# Altium schematic sheet JacdacBrainF4 41.SchDoc
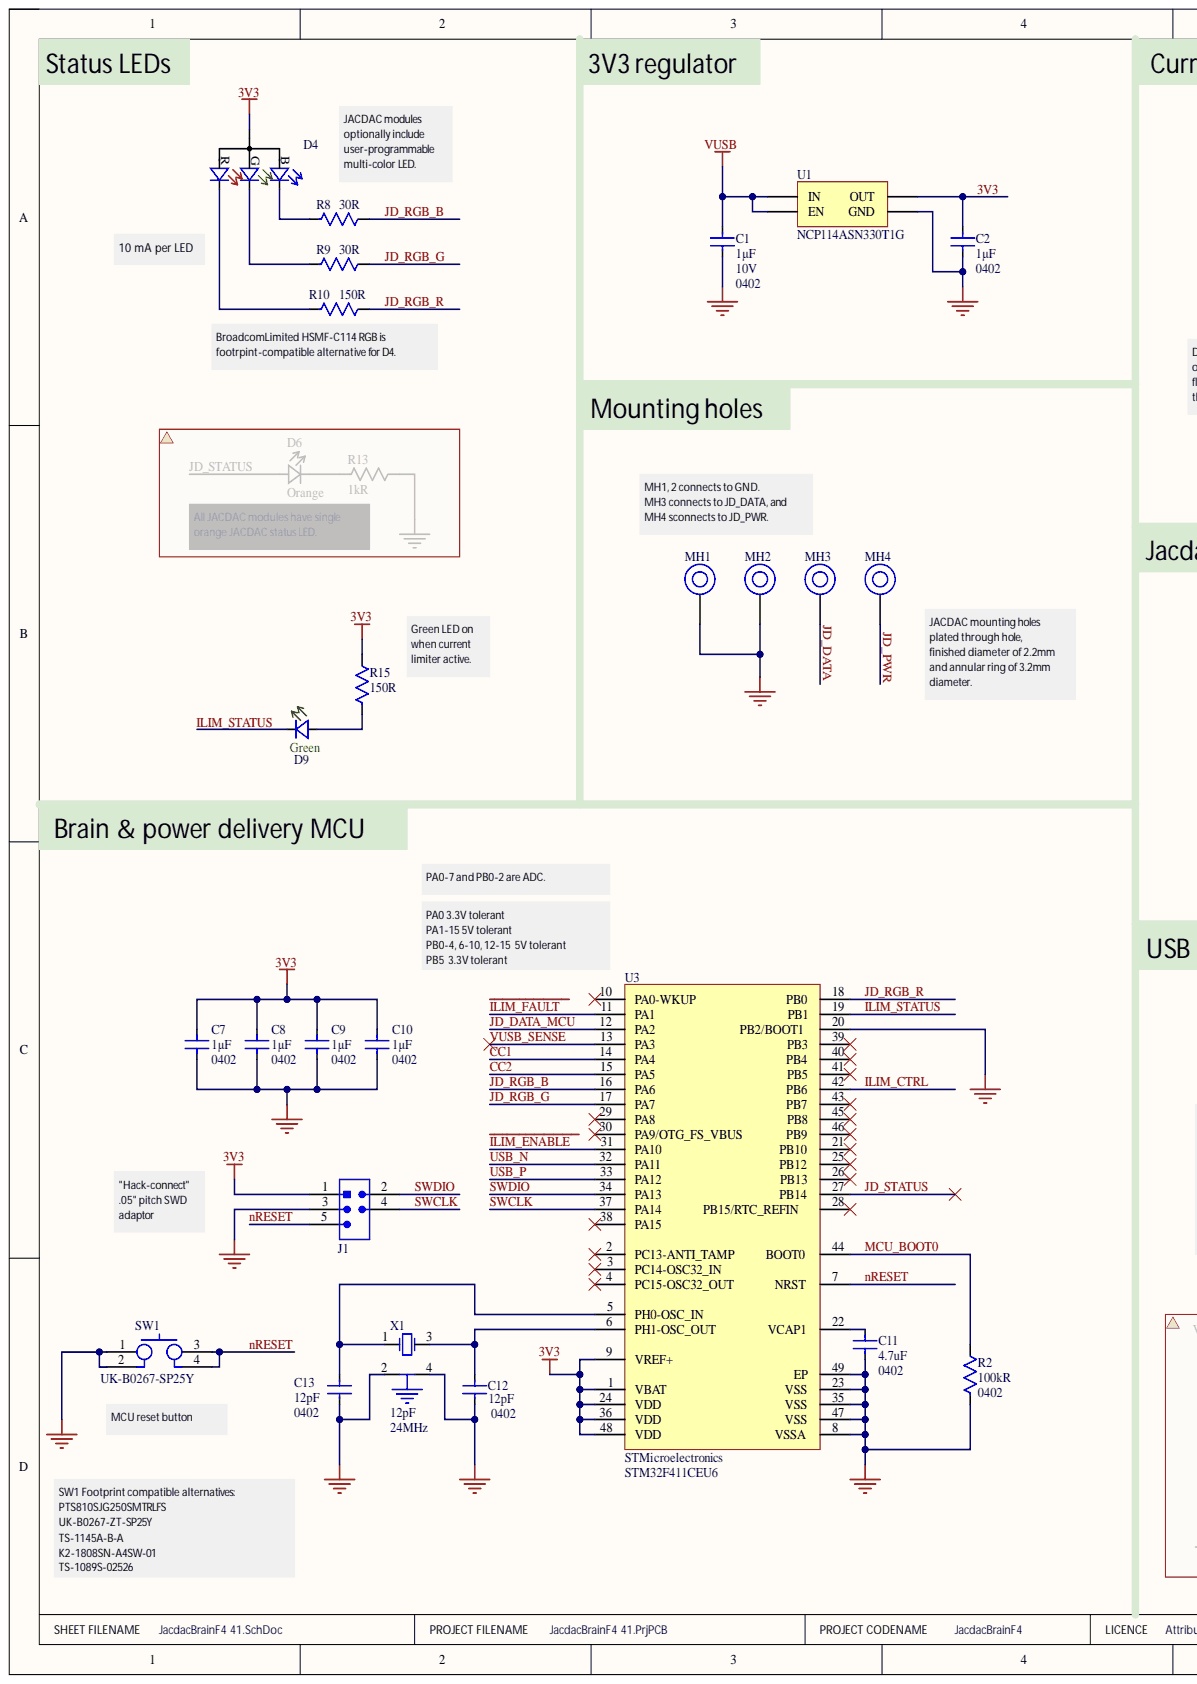
[diagram p0: JacdacBrainF4 41.SchDoc, left]
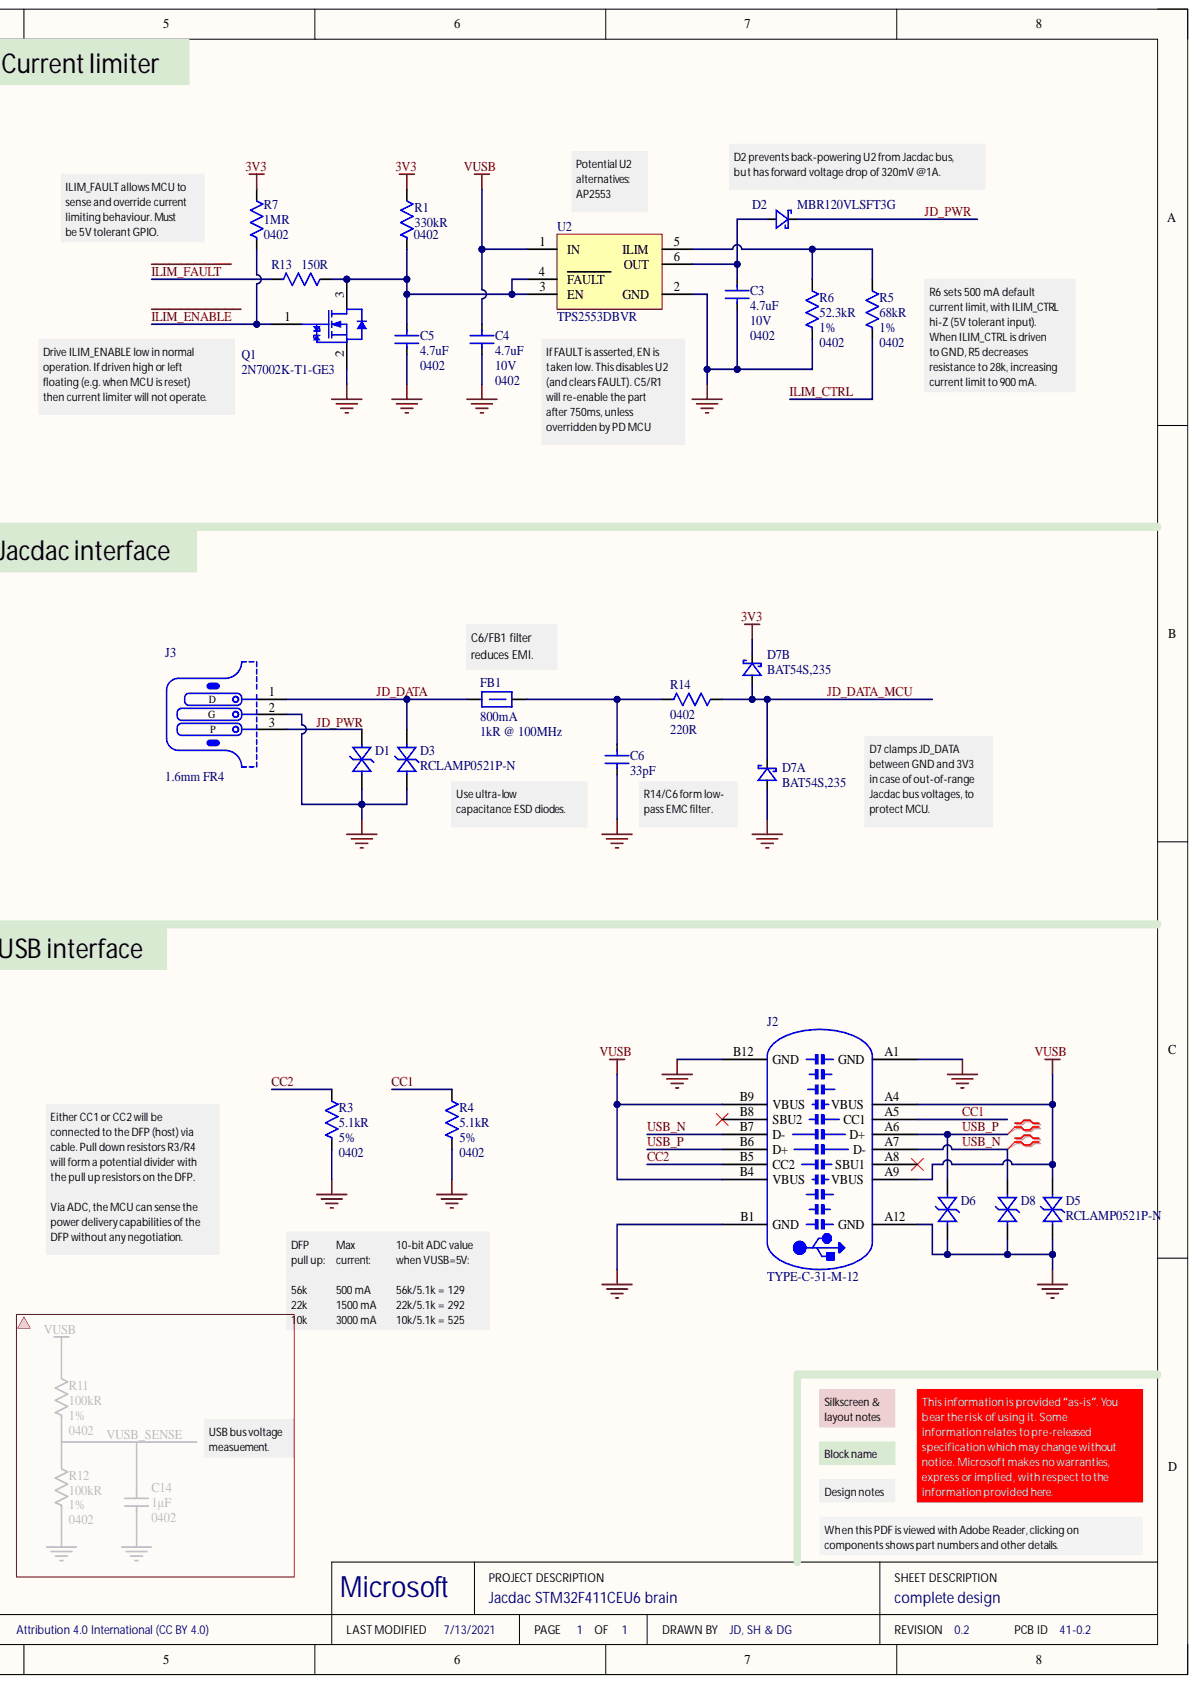
[diagram p1: JacdacBrainF4 41.SchDoc, right]

## Parts
C1  Capacitance: 1µF  Case Code (Imperial): 0402  Voltage Rating: 10V
C2  Capacitance: 1µF  Case Code (Imperial): 0402
C3  Capacitance: 4.7uF  Case Code (Imperial): 0402  Voltage Rating: 10V
C4  Capacitance: 4.7uF  Case Code (Imperial): 0402  Voltage Rating: 10V
C5  Capacitance: 4.7uF  Case Code (Imperial): 0402
C6  Capacitance: 33pF
C7  Capacitance: 1µF  Case Code (Imperial): 0402
C8  Capacitance: 1µF  Case Code (Imperial): 0402
C9  Capacitance: 1µF  Case Code (Imperial): 0402
C10  Capacitance: 1µF  Case Code (Imperial): 0402
C11  Capacitance: 4.7uF  Case Code (Imperial): 0402
C12  Capacitance: 12pF  Case Code (Imperial): 0402
C13  Capacitance: 12pF  Case Code (Imperial): 0402
D1  (no value)
D2
D2  Manufacturer Part Number: MBR120VLSFT3G
D3  Manufacturer Part Number: RCLAMP0521P-N
D4  (no value)
D5  Manufacturer Part Number: RCLAMP0521P-N
D6  (no value)
D7  Manufacturer Part Number: BAT54S,235  (parts A,B)
D8  (no value)
D9  Color: Green
FB1  Current Rating: 800mA  Impedance: 1kR @ 100MHz
J1  (no value)
J2  Manufacturer Part Number: TYPE-C-31-M-12
J3  PCB Type: 1.6mm FR4
MH1  (no value)
MH2  (no value)
MH3  (no value)
MH4  (no value)
Q1  Manufacturer Part Number: 2N7002K-T1-GE3
R1  Case Code (Imperial): 0402  Resistance: 330kR
R2  Case Code (Imperial): 0402  Resistance: 100kR
R3  Case Code (Imperial): 0402  Resistance: 5.1kR  Resistance Tolerance: 5%
R4  Case Code (Imperial): 0402  Resistance: 5.1kR  Resistance Tolerance: 5%
R5  Case Code (Imperial): 0402  Resistance: 68kR  Tolerance: 1%
R6  Case Code (Imperial): 0402  Resistance: 52.3kR  Tolerance: 1%
R7  Case Code (Imperial): 0402  Resistance: 1MR
R8  Resistance: 30R
R9  Resistance: 30R
R10  Resistance: 150R
R13  Resistance: 150R
R14  Case Code (Imperial): 0402  Resistance: 220R
R15  Resistance: 150R
SW1  Manufacturer Part Number: UK-B0267-SP25Y
U1  Manufacturer Part Number: NCP114ASN330T1G
U2  Manufacturer Part Number 1: TPS2553DBVR
U3  Manufacturer: STMicroelectronics  Manufacturer Part Number: STM32F411CEU6
X1  Frequency: 24MHz  Loading capacitance: 12pF

## Nets
GND: C1.1 C2.1 C3.1 C4.1 C5.1 C6.1 C7.1 C8.1 C9.1 C10.1 C11.1 C12.1 C13.1 D1.2 D3.2 D5.2 D6.2 D7.1 D8.2 J1.3 J2.A1(GND) J2.A12(GND) J2.B1(GND) J2.B12(GND) J3.2 MH1.1 MH2.1 Q1.2 R2.1 R3.2 R4.2 R6.1 SW1.1 SW1.2 U1.2(GND) U2.2(GND) U3.8(VSSA) U3.20(PB2/BOOT1) U3.23(VSS) U3.35(VSS) U3.47(VSS) U3.49(EP) X1.2 X1.4
VUSB: C1.2 C4.2 D5.1 J2.A4(VBUS) J2.A9(VBUS) J2.B4(VBUS) J2.B9(VBUS) U1.1(IN) U1.3(EN) U2.1(IN)
3V3: C2.2 C7.2 C8.2 C9.2 C10.2 D4.1 D7.2 J1.1 R1.1 R7.1 R15.2 U1.5(OUT) U3.1(VBAT) U3.9(VREF+) U3.24(VDD) U3.36(VDD) U3.48(VDD)
~{ILIM_ENABLE}: Q1.1 R7.2 U3.31(PA10)
~{ILIM_FAULT}: R13.1 U3.11(PA1)
CC1: J2.A5(CC1) R4.1 U3.14(PA4)
CC2: J2.B5(CC2) R3.1 U3.15(PA5)
ILIM_CTRL: R5.1 U3.42(PB6)
ILIM_STATUS: D9.1 U3.19(PB1)
JD_DATA: D3.1 FB1.1 J3.1 MH3.1
JD_DATA_MCU: D7.3 R14.1 U3.12(PA2)
JD_PWR: D1.1 D2.1 J3.3 MH4.1
JD_RGB_B: R8.2 U3.16(PA6)
JD_RGB_G: R9.2 U3.17(PA7)
JD_RGB_R: R10.2 U3.18(PB0)
JD_STATUS: U3.27(PB14)
MCU_BOOT0: R2.2 U3.44(BOOT0)
nRESET: J1.5 SW1.3 SW1.4 U3.7(NRST)
SWCLK: J1.4 U3.37(PA14)
SWDIO: J1.2 U3.34(PA13)
USB_N: D8.1 J2.A7(D-) J2.B7(D-) U3.32(PA11)
USB_P: D6.1 J2.A6(D+) J2.B6(D+) U3.33(PA12)
VUSB_SENSE: U3.13(PA3)
NetC3_2: C3.2 D2.2 U2.6(OUT)
NetC5_2: C5.2 Q1.3 R1.2 R13.2 U2.3(EN) U2.4(FAULT)
NetC6_2: C6.2 FB1.2 R14.2
NetC11_2: C11.2 U3.22(VCAP1)
NetC12_2: C12.2 U3.6(PH1-OSC_OUT) X1.3
NetC13_2: C13.2 U3.5(PH0-OSC_IN) X1.1
NetD4_2: D4.2 R10.1
NetD4_3: D4.3 R9.1
NetD4_4: D4.4 R8.1
NetD9_2: D9.2 R15.1
NetR5_2: R5.2 R6.2 U2.5(ILIM)

Not connected: J2 A8(SBU1) B8(SBU2); U3 2(PC13-ANTI_TAMP) 3(PC14-OSC32_IN) 4(PC15-OSC32_OUT) 10(PA0-WKUP) 21(PB10) 25(PB12) 26(PB13) 28(PB15/RTC_REFIN) 29(PA8) 30(PA9/OTG_FS_VBUS) 38(PA15) 39(PB3) 40(PB4) 41(PB5) 43(PB7) 45(PB8) 46(PB9)

## Library data of the parts
C1 C2 C7-C10 = (no symbol name): CAP CER 1UF 10V X5R 0402; Manufacturer: Samsung; Manufacturer Part Number: CL05A105KP5NNNC; Material: Ceramic; Tolerance: 10%; Case Code (Metric): 1005; Case/Package: 0402; Category: Capacitors; ComponentLink1Description: Online datasheet; ComponentLink1URL: https://datasheet.ciiva.com/15195/getdatasheetpartid-358390-15195630.pdf; Contact Plating: Tin; Dielectric: X5R; Max Operating Temperature: 85°C; Min Operating Temperature: -55°C; Supplier 1: Digi-Key; Supplier 2: Mouser; Supplier 3: Farnell; Supplier Part Number 1: 1276-1076-1-ND; Supplier Part Number 2: 187-CL05A105KP5NNNC; Supplier Part Number 3: 3013329
  C2 C7-C10: Voltage Rating: 10V
C3-C5 C11 = (no symbol name): CAP CER 4.7UF 10V X5R 0402; Manufacturer: Samsung; Manufacturer Part Number: CL05A475MP5NRNC; Tolerance: 20%; Case Code (Metric): 1005; Case/Package: 0402; Category: Ceramic Capacitors; ComponentLink1Description: Online datasheet; ComponentLink1URL: https://datasheet.ciiva.com/25786/cl05a475mp5nrnc-25786963.pdf; Dielectric: X5R; Max Operating Temperature: 85°C; Min Operating Temperature: -55°C; RoHS Compliant: Yes; Series: CL; Supplier 1: Digi-Key; Supplier 2: Future Electronics; Supplier Part Number 1: 1276-1482-2-ND; Supplier Part Number 2: 2103694
  C5 C11: Voltage Rating: 10V
C6 = (no symbol name): CAP CER 33PF 50V NP0 0402; Manufacturer: Samsung; Manufacturer Part Number: CL05C330JB51PNC; Tolerance: 5%; Case Code (Imperial): 0402; Case Code (Metric): 1005; Case/Package: 0402; Category: Capacitors; ComponentLink1Description: Samsung datasheet online; ComponentLink1URL: http://www.samsungsem.com/kr/support/library/product-catalog/mlcc.jsp; Max Operating Temperature: 125°C; Min Operating Temperature: -55°C; Ratings: AEC-Q200; Supplier 1: Digi-Key; Supplier 2: Mouser; Supplier 3: Future Electronics; Supplier Part Number 1: 1276-6515-1-ND; Supplier Part Number 2: 187-CL05C330JB51PNC; Supplier Part Number 3: 6022520; Voltage Rating: 50V
C12 C13 = (no symbol name): CAP CER 12PF 50V C0G/NP0 0402; Manufacturer: Samsung; Manufacturer Part Number: CL05C120JB5NNNC; Dielectric: C0G; Material: Ceramic; Temperature Coefficient: 300ppm/°C; Tolerance: 5%; Case Code (Metric): 1005; Case/Package: 0402; Category: Ceramic Capacitors; ComponentLink1Description: Online datasheet; ComponentLink1URL: https://datasheet.ciiva.com/25806/cl05c120jb5nnnc-25806484.pdf; Construction: Flat; Contact Plating: Tin; Max Operating Temperature: 125°C; Min Operating Temperature: -55°C; RoHS Compliant: Yes; Series: CL; Supplier 1: Digi-Key; Supplier 2: Mouser; Supplier Part Number 1: 1276-1178-1-ND; Supplier Part Number 2: 187-CL05C120JB5NNNC; Voltage: 50V; Voltage Rating: 50V; Voltage Rating (DC): 50V
D1 D6 D8 = (no symbol name): Bidirectional 5V (Max) 6V 16V 3.5A (8/20us) 0402 TVS RoHS; Manufacturer: Born Semiconductor; Manufacturer Part Number: RCLAMP0521P-N; ComponentLink1Description: Online datasheet; ComponentLink1URL: https://datasheet.lcsc.com/szlcsc/Bourne-Semicon-Shenzhen-RCLAMP0521P-N_C316043.pdf; Package: 0402; Supplier1: LCSC; Supplier1 Part Number: C316043
D2 = (no symbol name): DIODE SCHOTTKY 20V 1A SOD123FL; Manufacturer: ON Semiconductor; Element Configuration: Single; Forward Voltage: 340mV; Max Forward Surge Current (Ifsm): 45A; Max Reverse Leakage Current: 600uA; Polarity: Standard; Average Rectified Current: 1A; Category: Schottky Diodes; ComponentLink4Description: On Semi datasheet online; ComponentLink4URL: https://datasheet.ciiva.com/6380/mbr120vlsft1-d-6380910.pdf; Current Rating: 1A; Forward Current: 1A; Halogen Free: Halogen Free; Max Operating Temperature: 125°C; Max Repetitive Reverse Voltage (Vrrm): 20V; Max Reverse Voltage (DC): 20V; Max Surge Current: 45A; Min Operating Temperature: -65°C; Number of Pins: 2; Output Current: 1A; Peak Non-Repetitive Surge Current: 45A; Peak Reverse Current: 600uA; Supplier 1: Digi-Key; Supplier 2: Mouser; Supplier 3: RSComponents; Supplier Part Number 1: MBR120VLSFT3GOSCT-ND; Supplier Part Number 2: 863-MBR120VLSFT3G; Supplier Part Number 3: 1867479; Voltage Rating (DC): 20V
D3 D5 = (no symbol name): Bidirectional 5V (Max) 6V 16V 3.5A (8/20us) 0402 TVS RoHS; Manufacturer: Born Semiconductor; ComponentLink1Description: Online datasheet; ComponentLink1URL: https://datasheet.lcsc.com/szlcsc/Bourne-Semicon-Shenzhen-RCLAMP0521P-N_C316043.pdf; Package: 0402; Supplier1: LCSC; Supplier1 Part Number: C316043
D4 = (no symbol name): 1615 Light Emitting Diodes (LED) RoHS; Manufacturer: TUOZHAN; Manufacturer Part Number: TZ-P4-1615RGBTCA1-0.55T; Category: Optoelectronics; ComponentLink1Description: Online datasheet; ComponentLink1URL: https://datasheet.lcsc.com/szlcsc/2009041238_TUOZHAN-TZ-P4-1615RGBTCA1-0-55T_C779813.pdf; Package: 1615; Size / Dimension: 1.6x1.5mm; Supplier 1: LCSC; Supplier 1 Part Number: C779813
D7 = (no symbol name): DIODE ARRAY SCHOTTKY 30V SOT23; Manufacturer: Nexperia; Category: Rectifier Diodes; ComponentLink1Description: NXP datasheet online; ComponentLink1URL: https://assets.nexperia.com/documents/data-sheet/BAT54_SER.pdf; Supplier 1: Digi-Key; Supplier 2: Mouser; Supplier 3: RSComponents; Supplier Part Number 1: 1727-1868-1-ND; Supplier Part Number 2: 771-BAT54S235; Supplier Part Number 3: 1707990
D9 = (no symbol name): LED GREEN DIFFUSED 0603 SMD; Manufacturer: Everlight Elec; Manufacturer Part Number: 19-217/GHC-YR1S2/3T; Case Code (Imperial): 0603; Case/Package: 0603; Colour: Green; ComponentLink1Description: Online datasheet; ComponentLink1URL: https://datasheet.lcsc.com/lcsc/1811101510_Everlight-Elec-19-217-GHC-YR1S2-3T_C72043.pdf; Supplier 1: LCSC; Supplier 1 Part Number: C72043
FB1 = (no symbol name): 0603 1k@100MHz 0.8A chip; Manufacturer: TDK; Manufacturer Part Number: MPZ1608S102ATA00; Core Material: Ferrite; DC Resistance (DCR): 300mR; Tolerance: 25%; Case Code (Imperial): 0603; Case Code (Metric): 1608; Case/Package: 0603; ComponentLink2Description: datasheet online; ComponentLink2URL: https://www.mouser.co.uk/datasheet/2/400/eads_commercial_power_mpz1608_en-923650.pdf; ComponentLink3Description: datasheet; Max DC Current: 800mA; Supplier 1: Digi-Key; Supplier 2: Mouser; Supplier Part Number 1: 445-8694-1-ND; Supplier Part Number 2: 810-MPZ1608S102ATA00; ComponentLink3URL: Y:\System\Altium\Libraries 2014\TDK\Datasheets\eads_commercial_power_mpz1608_en-923650.pdf
J1 = (no symbol name): Hack Connect Mini 1.27 mm pitch 2-row
J2 = (no symbol name): USB - Type C Female 16 USB Connectors RoHS; Manufacturer: Korean Hroparts Elec; Gender: Receptacle; Number of Positions: 16; Category: USB Connectors; ComponentLink1Description: Online datasheet; ComponentLink1URL: https://datasheet.lcsc.com/szlcsc/1811131825_Korean-Hroparts-Elec-TYPE-C-31-M-12_C165948.pdf; Orientation: Right Angle; Supplier 1: LCSC; Supplier 1 Part Number: C165948
J3 = (no symbol name): 3 pin jacdac edge connector 1.27mm pitch; Manufacturer Part Number: JACDAC_EDGE_CONNECTOR; ComponentLink1Description: JACDAC PCB edge connector spec onlin; ComponentLink1URL: https://github.com/microsoft/jacdac-mdk/blob/main/connector/JACDAC_PCB_Edge_Connector_Drawing_JD-PEC-01_rev_01.pdf; ComponentLink2Description: Yuliang JACDAC cable connector drawing online; ComponentLink2URL: https://github.com/microsoft/jacdac-mdk/blob/main/connector/JACDAC-Connector-by-Yuliang-2020-11-28.pdf
MH1-MH4 = (no symbol name): Mounting Hole 5.0mm keepout, 3.0mm annualar ring and 2.1mm Drill PTH
Q1 = (no symbol name): Trans MOSFET N-CH Si 60V 0.3A 3-Pin SOT-23 T/R; Manufacturer: Vishay; Continuous Drain Current (ID): 300mA; Drain to Source Resistance: 2R; Element Configuration: Single; Gate to Source Voltage (Vgs): 20V; Input Capacitance: 30pF; Max Power Dissipation: 350mW; Nominal Vgs: 2V; Number of Channels: 1; Threshold Voltage: 1V; Turn-Off Delay Time: 35ns; Turn-On Delay Time: 25ns; Case/Package: SOT-23; Category: MOSFETs; ComponentLink1Description: Online datasheet; ComponentLink1URL: https://datasheet.ciiva.com/2957/2n7002k-2957216.pdf; Contact Plating: Tin,Matte; Drain to Source Breakdown Voltage: 60V; Drain to Source Voltage (Vdss): 60V; Max Operating Temperature: 150°C; Min Operating Temperature: -55°C; Number of Elements: 1; Number of Pins: 3; On-State Resistance: 2R; Power Dissipation: 350mW; Rds On Max: 2R; Supplier 1: Digi-Key; Supplier 2: RSComponents; Supplier Part Number 1: 2N7002K-T1-GE3CT-ND; Supplier Part Number 2: 7879058P; Voltage: 60V; Weight: 0.050717oz
R1 = (no symbol name): RES SMD 330K OHM 1% 1/16W 0402; Manufacturer: Yageo; Manufacturer Part Number: RC0402FR-07330KL; Max Power Dissipation: 63mW; Temperature Coefficient: 100ppm/°C; Voltage Rating: 50V; Case Code (Metric): 1005; Case/Package: 0402; Category: Chip SMD Resistors; ComponentLink1Description: Online datasheet; ComponentLink1URL: https://datasheet.ciiva.com/2518/pyu-r-int-thick-7-2518994.pdf?src-supplier=Digi-Key; Construction: Rectangular; Features: Moisture Resistant; Max Operating Temperature: 155°C; Min Operating Temperature: -55°C; Number of Terminations: 2; Power Rating: 63mW; Resistance Tolerance: 1%; Resistor Type: Thick Film; RoHS Compliant: Yes; Series: RC; Size Code: 0402; Supplier 1: Digi-Key; Supplier 2: Mouser; Supplier 3: Farnell; Supplier Part Number 1: 311-330KLRCT-ND; Supplier Part Number 2: 603-RC0402FR-07330KL; Supplier Part Number 3: 9239537; Termination: SMD/SMT; Tolerance: 1%
R2 = (no symbol name): RES SMD 100K OHM 1% 1/16W 0402; Manufacturer: Yageo; Manufacturer Part Number: RC0402FR-07100KL; Max Power Dissipation: 63mW; Temperature Coefficient: 100ppm/°C; Voltage Rating: 50V; Case Code (Metric): 1005; Case/Package: 0402; Category: Resistors; ComponentLink2Description: Online datasheet; ComponentLink2URL: https://datasheet.ciiva.com/2453/pyu-r-int-thick-7-2453361.pdf; Features: Moisture Resistant; Max Operating Temperature: 155°C; Min Operating Temperature: -55°C; Number of Terminations: 2; Power Rating: 63mW; Resistor Type: Thick Film; Supplier 1: Digi-Key; Supplier 2: Mouser; Supplier 3: Farnell; Supplier Part Number 1: 311-100KLRCT-ND; Supplier Part Number 2: 603-RC0402FR-07100KL; Supplier Part Number 3: 2131798; Termination: SMD/SMT; Tolerance: 1%; Working Voltage: 50V
R3 R4 = (no symbol name): RES SMD 5.1K OHM 5% 1/16W 0402; Manufacturer: Yageo; Manufacturer Part Number: RC0402JR-075K1L; Max Power Dissipation: 63mW; Power Rating: 62.5mW; Temperature Coefficient: 100ppm/°C; Voltage Rating: 50V; Case Code (Metric): 1005; Case/Package: 0402; Category: Chip SMD Resistors; ComponentLink2Description: Onnline datasheet; ComponentLink2URL: https://datasheet.ciiva.com/16740/pyu-rc0402-51-rohs-l-6-r-16740925.pdf; Construction: Rectangular; Features: Moisture Resistant; Max Operating Temperature: 155°C; Min Operating Temperature: -55°C; Number of Terminations: 2; Resistor Type: Thick Film; RoHS Compliant: Yes; Series: RC; Size Code: 0402; Supplier 1: Digi-Key; Supplier 2: Newark; Supplier 3: Mouser; Supplier 4: Farnell; Supplier 5: Farnell; Supplier Part Number 1: 311-5.1KJRCT-ND; Supplier Part Number 2: 68R0016; Supplier Part Number 3: 603-RC0402JR-075K1L; Supplier Part Number 4: 3495714; Supplier Part Number 5: 1799293; Termination: SMD/SMT; Tolerance: 5%; Voltage Rating (DC): 50V; Weight: 2.3E-05oz
R5 = (no symbol name): RES SMD 68K OHM 1% 1/16W 0402; Manufacturer: Yageo; Manufacturer Part Number: RT0402FRE0768KL; Max Power Dissipation: 63mW; Power Rating: 62.5mW; Temperature Coefficient: 50ppm/°C; Voltage Rating: 50V; Case Code (Metric): 1005; Case/Package: 0402; Category: Chip SMD Resistors; ComponentLink1Description: Online datasheet; ComponentLink1URL: https://datasheet.ciiva.com/2561/pyu-rt-1-to-0-05-rohs-l-5-2561824.pdf?src-supplier=Digi-Key; Contact Plating: Tin,Matte; Max Operating Temperature: 125°C; Min Operating Temperature: -55°C; Number of Terminations: 2; Resistor Type: Thin Film; RoHS Compliant: Yes; Series: RT; Supplier 1: Digi-Key; Supplier 2: Mouser; Supplier Part Number 1: 13-RT0402FRE0768KLCT-ND; Supplier Part Number 2: 603-RT0402FRE0768KL; Termination: SMD/SMT; Voltage Rating (DC): 50V
R6 = (no symbol name): RES SMD 52.3K OHM 1% 1/16W 0402; Manufacturer: Yageo; Manufacturer Part Number: RC0402FR-0752K3L; Max Power Dissipation: 63mW; Power Rating: 62.5mW; Temperature Coefficient: 100ppm/°C; Voltage Rating: 50V; Case Code (Metric): 1005; Case/Package: 0402; Category: Chip SMD Resistors; ComponentLink1Description: Oline datasheet; ComponentLink1URL: https://datasheet.ciiva.com/16867/pyu-rc0402-51-rohs-l-6-16867930.pdf; Construction: Rectangular; Contact Plating: Tin,Matte; Features: Moisture Resistant; Max Operating Temperature: 155°C; Min Operating Temperature: -55°C; Number of Terminations: 2; Resistor Type: Thick Film; RoHS Compliant: Yes; Series: RC; Size Code: 0402; Supplier 1: Digi-Key; Supplier 2: Mouser; Supplier Part Number 1: YAG3179CT-ND; Supplier Part Number 2: 603-RC0402FR-0752K3L; Termination: SMD/SMT; Voltage Rating (DC): 50V; Weight: 2.3E-05oz
R7 = (no symbol name): RES SMD 1M OHM 1% 1/16W 0402; Manufacturer: Yageo; Manufacturer Part Number: RC0402FR-071ML; Max Power Dissipation: 63mW; Temperature Coefficient: 100ppm/°C; Voltage Rating: 50V; Case Code (Metric): 1005; Case/Package: 0402; Category: Chip SMD Resistors; ComponentLink1Description: Online datasheet; ComponentLink1URL: https://datasheet.ciiva.com/11808/0900766b80c66297-11808125.pdf; Construction: Rectangular; Features: Moisture Resistant; Max Operating Temperature: 155°C; Min Operating Temperature: -55°C; Number of Terminations: 2; Power Rating: 63mW; Resistance Tolerance: 1%; Resistor Type: Thick Film; RoHS Compliant: Yes; Series: RC; Size Code: 0402; Supplier 1: Digi-Key; Supplier 2: Mouser; Supplier 3: Farnell; Supplier Part Number 1: 311-1.00MLRCT-ND; Supplier Part Number 2: 603-RC0402FR-071ML; Supplier Part Number 3: 2421892; Technology: THICK FILM; Termination: SMD/SMT; Tolerance: 1%
R8 R9 = (no symbol name): RES SMD 30 OHM 1% 1/16W 0402; Manufacturer: Yageo; Manufacturer Part Number: RC0402FR-0730RL; Max Power Dissipation: 63mW; Temperature Coefficient: 100ppm/°C; Voltage Rating: 50V; Case Code (Imperial): 0402; Case Code (Metric): 1005; Case/Package: 0402; Category: Chip SMD Resistors; ComponentLink1Description: Online datasheet; ComponentLink1URL: https://datasheet.ciiva.com/7849/1018594-7849958.pdf; Construction: Rectangular; Contact Plating: Tin,Matte; Features: Moisture Resistant; Max Operating Temperature: 155°C; Min Operating Temperature: -55°C; Number of Terminations: 2; Power Rating: 63mW; Resistance Tolerance: 1%; Resistor Type: Thick Film; RoHS Compliant: Yes; Series: RC; Size Code: 0402; Supplier 1: Digi-Key; Supplier 2: Mouser; Supplier 3: Farnell; Supplier Part Number 1: 311-30LRCT-ND; Supplier Part Number 2: 603-RC0402FR-0730RL; Supplier Part Number 3: 1458775; Termination: SMD/SMT; Tolerance: 1%; Voltage Rating (DC): 50V
R10 R13 R15 = (no symbol name): RES SMD 150 OHM 1% 1/16W 0402; Manufacturer: Yageo; Manufacturer Part Number: RC0402FR-07150RL; Max Power Dissipation: 63mW; Power Rating: 62.5mW; Temperature Coefficient: 100ppm/°C; Voltage Rating: 50V; Case Code (Imperial): 0402; Case Code (Metric): 1005; Case/Package: 0402; Category: Chip SMD Resistors; ComponentLink1Description: ine datasheet; ComponentLink1URL: https://datasheet.ciiva.com/11808/0900766b80c66297-11808422.pdf; Construction: Rectangular; Features: Moisture Resistant; Max Operating Temperature: 155°C; Min Operating Temperature: -55°C; Number of Terminations: 2; Resistance Tolerance: 1%; Resistor Type: Thick Film; RoHS Compliant: Yes; Series: RC; Supplier 1: Digi-Key; Supplier 2: Mouser; Supplier 3: Farnell; Supplier Part Number 1: 311-150LRCT-ND; Supplier Part Number 2: 603-RC0402FR-07150RL; Supplier Part Number 3: 2421893; Technology: THICK FILM; Termination: SMD/SMT; Tolerance: 1%; Working Voltage: 50V
R14 = (no symbol name): RES SMD 220 OHM 1% 1/16W 0402; Manufacturer: Yageo; Manufacturer Part Number: RC0402FR-07220RL; Max Power Dissipation: 63mW; Power Rating: 62.5mW; Temperature Coefficient: 100ppm/°C; Voltage Rating: 50V; Case Code (Metric): 1005; Case/Package: 0402; Category: Resistors; ComponentLink1Description: Online datasheet; ComponentLink1URL: https://datasheet.ciiva.com/11819/0900766b80c66297-11819466.pdf; Features: Moisture Resistant; Max Operating Temperature: 155°C; Min Operating Temperature: -55°C; Number of Pins: 2; Number of Terminations: 2; Resistor Type: Thick Film; Supplier 1: Digi-Key; Supplier 2: Mouser; Supplier 3: Farnell; Supplier Part Number 1: 311-220LRCT-ND; Supplier Part Number 2: 603-RC0402FR-07220RL; Supplier Part Number 3: 2147864; Termination: SMD/SMT; Tolerance: 1%; Voltage Rating (DC): 50V
SW1 = (no symbol name): SWITCH TACTILE SSPST 50mA @ 12VDC; Manufacturer: USAKRO; Actuator: Oval; Contact Form: SPST; Operating Force: 3.9 N; Switch Function: OFF - (ON); ComponentLink1Description: Online datasheet; ComponentLink1URL: https://datasheet.ciiva.com/18514/pts810-4mar13-18514167.pdf; Current Rating: 50 mA; Mounting Style: SMD/SMT; Product Category: Tactile Switches; Supplier 1: LCSC; Supplier 1 Part Number: C493283; Voltage Rating DC: 12 VDC
U1 = (no symbol name): ON SEMICONDUCTOR - NCP114ASN330T1G - LDO Voltage Regulator, Fixed, 1.7 V to 5.5 V in, 3.3 V/300 mA out, 150 mV drop, TSOP-5; Dropout Voltage: 225mV; Max Input Voltage: 5.5V; Min Output Voltage: 3.3V; Number of Regulators: 1; Output Configuration: Positive; Output Current: 300mA; Power Supply Rejection Ratio (PSRR): 75dB; Quiescent Current: 95uA; Case/Package: SOT-23-5; Category: Voltage Regulators - Linear; ComponentLink3Description: On Semi datasheet online; ComponentLink3URL: http://www.onsemi.com/pub/Collateral/NCP114-D.PDF; Manufacturer: ON Semiconductor; Max Operating Temperature: 85°C; Min Operating Temperature: -40°C; Output Type: Fixed; Supplier 1: Digi-Key; Supplier 2: RSComponents; Supplier 3: Mouser; Supplier Part Number 1: NCP114ASN330T1GOSCT-ND; Supplier Part Number 2: 1263178; Supplier Part Number 3: 863-NCP114ASN330T1G
U2 = (no symbol name): POWER LOAD SWITCH, HIGH SIDE, SOT-23-6; Power Load Switch Type:High Side; Input Voltage:6.5V; Current Limit:1.7A; On State Resistance:0.085ohm; Distribution Switch Case Style:SOT-23; No. of Pins:6; Thermal Protection:Yes; On / Enable;RoHS Compliant: Yes; Manufacturer 1: Texas Instruments; Max Input Voltage: 6.5V; Max Power Dissipation: 625mW; Min Input Voltage: 2.5V; Number of Outputs: 1; On-State Resistance: 85mR; Output Current: 1.5A; Case/Package: SOT-23; Category: Hot Swap Controllers; ComponentLink1Description: https://datasheet.ciiva.com/15108/getdatasheetpartid-297517-15108509.pdf?src-supplier=Verical; ComponentLink1URL: https://datasheet.ciiva.com/15108/getdatasheetpartid-297517-15108509.pdf?src-supplier=Verical; Max Operating Temperature: 85°C; Max Supply Voltage: 6.5V; Min Operating Temperature: -40°C; Min Supply Voltage: 2.5V; Number of Pins: 6; Supplier 1: Digi-Key; Supplier 2: Farnell; Supplier 3: Mouser; Supplier Part Number 1: 296-32464-1-ND; Supplier Part Number 2: 3124082; Supplier Part Number 3: 595-TPS2553DBVR; Weight: 0.00127oz
U3 = (no symbol name): ARM Cortex M4 MCU+FPU 512k Flash, 128k RAM, 100MHz, QFPN48; Data Bus Width: 32b; Interface: I2C,I2S,IrDA,LIN,MMC,SD,SDIO,SPI,UART,USART,USB; Max Power Dissipation: 625mW; Max Supply Voltage: 3.6V; Memory Size: 512KB; Min Supply Voltage: 1.7V; Number of ADC Channels: 16; Number of I/Os: 36; Number of Timers/Counters: 8; Operating Supply Voltage: 3.3V; Oscillator Type: Internal; Peripherals: Brown-out Detect/Reset,DMA,POR,PWM,WDT; RAM Size: 128KB; Watchdog Timer: Yes; Case/Package: UFQFPN; ComponentLink1Description: ST datasheet; ComponentLink1URL: https://www.st.com/resource/en/datasheet/stm32f411vc.pdf; Core Architecture: ARM; Frequency: 100MHz; Max Frequency: 100MHz; Max Operating Temperature: 85°C; Memory Type: FLASH; Min Operating Temperature: -40°C; Number of Pins: 48; Number of Programmable I/O: 36; Supplier 1: Digi-Key; Supplier 2: Farnell; Supplier 3: Mouser; Supplier 4: RSComponents; Supplier Part Number 1: 497-14907-ND; Supplier Part Number 2: 2456965; Supplier Part Number 3: 511-STM32F411CEU6; Supplier Part Number 4: 1106616
X1 = (no symbol name): 24MHz ±10ppm 12pF 40Ω SMD-3225_4P SMD Crystal Resonators RoHS; Manufacturer: JWT; Manufacturer Part Number: CF4024M00012T8188046; ESR: 40R; Suplier 1: LCSC; ComponentLink1Description: Online datasheet; ComponentLink1URL: https://datasheet.lcsc.com/szlcsc/2008211534_JWT-CF4024M00012T8188046_C709021.pdf; Supplier 1 Part Number: C709021; Tolerance: ±10ppm
